# Altium schematic sheet BLEspresso.100.PowerSupplies.SchDoc(U_BLEspresso)
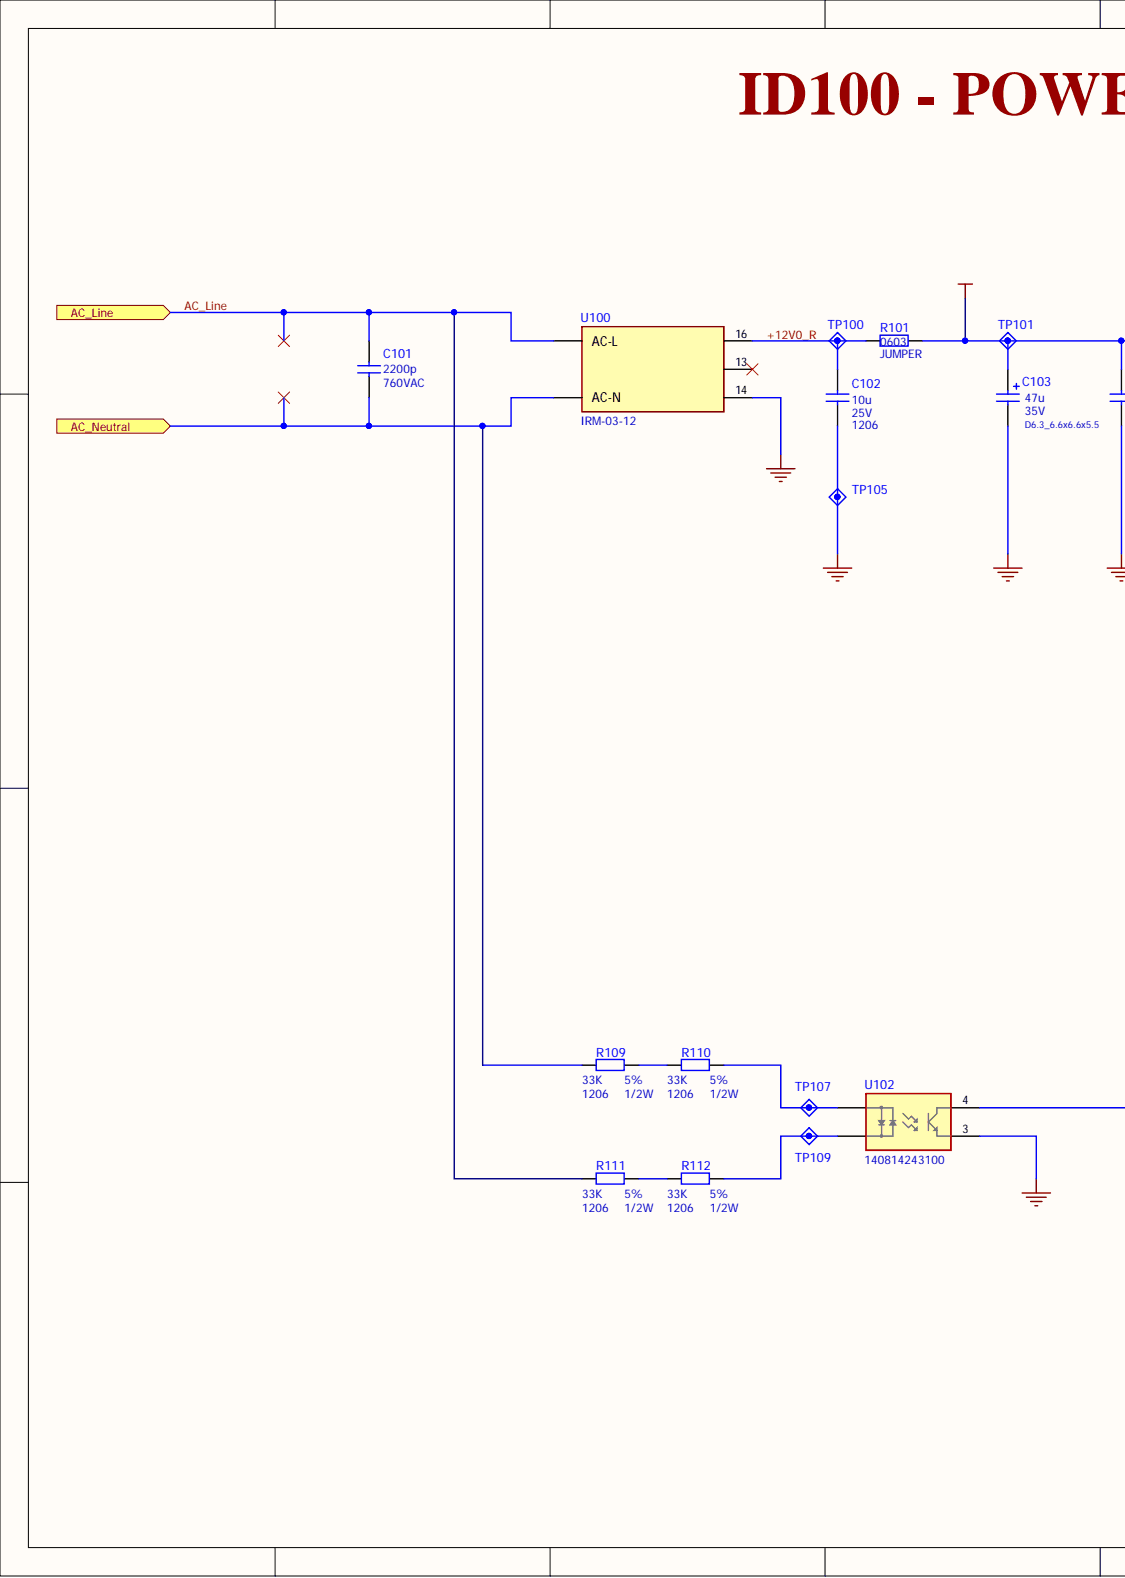
[diagram p0: BLEspresso.100.PowerSupplies.SchDoc, left]
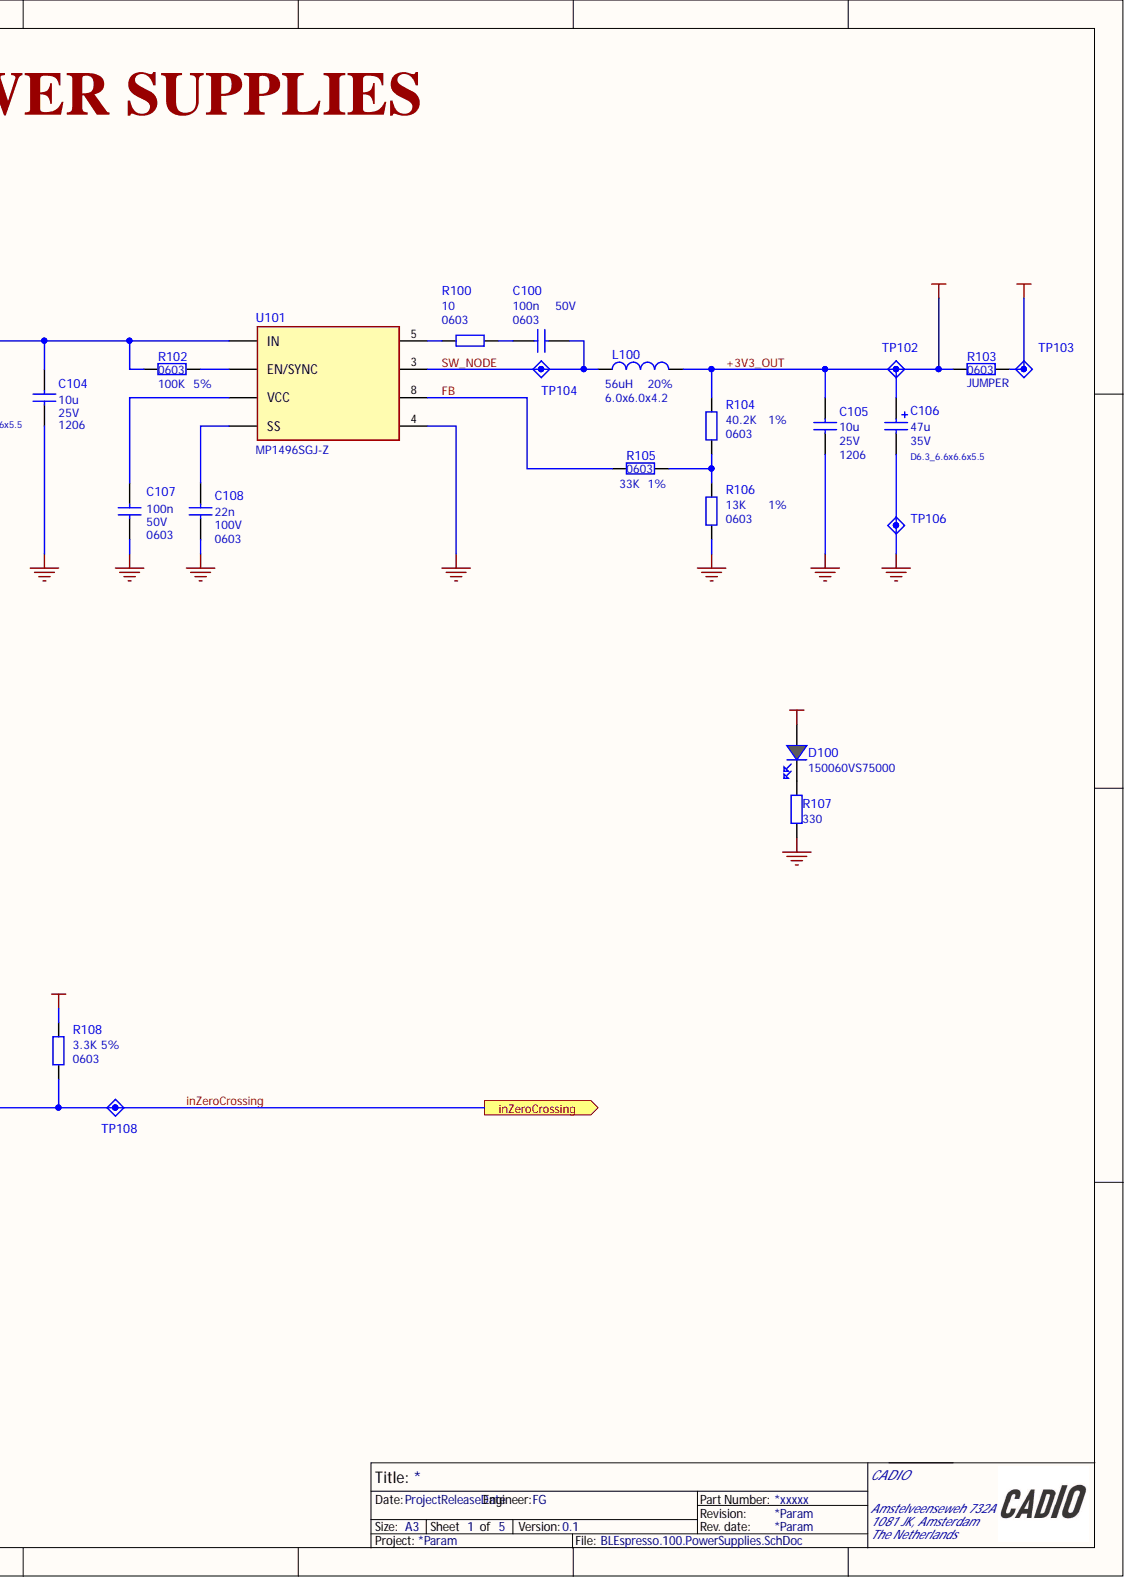
[diagram p1: BLEspresso.100.PowerSupplies.SchDoc, right]

## Parts
C100  Part Value 2: 50V  Part Value: 100n  Part Package: 0603  [CAP_0603_1608X90-N_MURATA_A]  (hidden comment: #NAME?)
C101  Part Value 2: 760VAC  Part Value: 2200p  [VISHAY_P1250_VY1_A]  (hidden comment: #NAME?)
C102  Part Value 2: 25V  Part Value: 10u  Part Package: 1206  [CAP_1206_3216X160-N_MURATA_A]  (hidden comment: #NAME?)
C103  Part Value 2: 35V  Part Value: 47u  Part Package: D6.3_6.6x6.6x5.5  [CAPAE_55_660X660X520L265X65-N_KEMET_A]  (hidden comment: #NAME?)
C104  Part Value 2: 25V  Part Value: 10u  Part Package: 1206  [CAP_1206_3216X160-N_MURATA_A]  (hidden comment: #NAME?)
C105  Part Value 2: 25V  Part Value: 10u  Part Package: 1206  [CAP_1206_3216X160-N_MURATA_A]  (hidden comment: #NAME?)
C106  Part Value 2: 35V  Part Value: 47u  Part Package: D6.3_6.6x6.6x5.5  [CAPAE_55_660X660X520L265X65-N_KEMET_A]  (hidden comment: #NAME?)
C107  Part Value 2: 50V  Part Value: 100n  Part Package: 0603  [CAP_0603_1608X90-N_MURATA_A]  (hidden comment: #NAME?)
C108  Part Value 2: 100V  Part Value: 22n  Part Package: 0603  [CAP_0603_1608X90-N_MURATA_A]  (hidden comment: #NAME?)
D100  Manufacturer Part Number: 150060VS75000  [LED_GREEN_0603-N_LITEON_A]  (hidden comment: #NAME?)
L100  Part Value 2: 20%  Part Value: 56uH  Part Package: 6.0x6.0x4.2  [BOURNS_SRN6045TA _A]  (hidden comment: #NAME?)
R100  Part Package: 0603  [RES_0603_1608X60-N_YAGEO_A]  (hidden comment: #NAME?)
R101  Part Value: JUMPER  Part Package: 0603  [RES_0603_1608X60-N_YAGEO_A]  (hidden comment: #NAME?)
R102  Part Value 2: 5%  Part Value: 100K  Part Package: 0603  [CAP_0603_1608X95-N_MURATA_A]  (hidden comment: #NAME?)
R103  Part Value: JUMPER  Part Package: 0603  [RES_0603_1608X60-N_YAGEO_A]  (hidden comment: #NAME?)
R104  Part Value: 40.2K  Part Package: 0603  [RES_0603_1608X60-N_YAGEO_A]  (hidden comment: #NAME?)
R105  Part Value 2: 1%  Part Value: 33K  Part Package: 0603  [RES_0603_1608X60-N_YAGEO_A]  (hidden comment: #NAME?)
R106  Part Value: 13K  Part Package: 0603  [RES_0603_1608X60-N_YAGEO_A]  (hidden comment: #NAME?)
R107  #NAME?  [RES_0603_1608X60-N_YAGEO_A]  (value not drawn)
R108  Part Value: 3.3K  Part Package: 0603  [RES_0603_1608X60-N_YAGEO_A]  (hidden comment: #NAME?)
R109  Part Value 3: 1/2W  Part Value 2: 5%  Part Value: 33K  Part Package: 1206  [RES_1206_3216X65-N_YAGEO_A]  (hidden comment: #NAME?)
R110  Part Value 3: 1/2W  Part Value 2: 5%  Part Value: 33K  Part Package: 1206  [RES_1206_3216X65-N_YAGEO_A]  (hidden comment: #NAME?)
R111  Part Value 3: 1/2W  Part Value 2: 5%  Part Value: 33K  Part Package: 1206  [RES_1206_3216X65-N_YAGEO_A]  (hidden comment: #NAME?)
R112  Part Value 3: 1/2W  Part Value 2: 5%  Part Value: 33K  Part Package: 1206  [RES_1206_3216X65-N_YAGEO_A]  (hidden comment: #NAME?)
TP100  Test Point 0D8 A  [TESTPOINT_0D8_A]  (value not drawn)  (no BOM)
TP101  Test Point 0D8 A  [TESTPOINT_0D8_A]  (value not drawn)  (no BOM)
TP102  Test Point 0D8 A  [TESTPOINT_0D8_A]  (value not drawn)  (no BOM)
TP103  Test Point 0D8 A  [TESTPOINT_0D8_A]  (value not drawn)  (no BOM)
TP104  Test Point 0D8 A  [TESTPOINT_0D8_A]  (value not drawn)  (no BOM)
TP105  Test Point 0D8 A  [TESTPOINT_0D8_A]  (value not drawn)  (no BOM)
TP106  Test Point 0D8 A  [TESTPOINT_0D8_A]  (value not drawn)  (no BOM)
TP107  Test Point 0D8 A  [TESTPOINT_0D8_A]  (value not drawn)  (no BOM)
TP108  Test Point 0D8 A  [TESTPOINT_0D8_A]  (value not drawn)  (no BOM)
TP109  Test Point 0D8 A  [TESTPOINT_0D8_A]  (value not drawn)  (no BOM)
U100  Manufacturer Part Number: IRM-03-12  [MEANWELL_IRM03_A]  (hidden comment: #NAME?)
U101  Manufacturer Part Number: MP1496SGJ-Z  [SOT23-8P65_290X160L40X30-N_MPS_A]  (hidden comment: #NAME?)
U102  Manufacturer Part Number: 140814243100  [SOP4P254_458X1015X360L80X145-N_EVERLIGHT_A]  (hidden comment: #NAME?)

## Ports
AC_Line, AC_Neutral, inZeroCrossing

## Nets
+3V3: R103.2 R108.2 TP103.1
+12V0: C103.1 C104.1 R101.2 R102.1 TP101.1 U101.2(IN)
GND: C102.2 C103.2 C104.2 C105.2 C106.2 C107.2 C108.2 R106.1 R107.1 TP105.1 TP106.1 U100.14 U101.4 U102.3
+3V3_OUT: C105.1 C106.1 D100.A L100.2 R103.1 R104.2 TP102.1
+12V0_R: C102.1 R101.1 TP100.1 U100.16
AC_Line: C101.1 R111.1 U100.1(AC-L) ; port AC_Line
AC_Neutral: C101.2 R109.1 U100.3(AC-N) ; port AC_Neutral
FB: R105.1 U101.8
inZeroCrossing: R108.1 TP108.1 U102.4 ; port inZeroCrossing
SW_NODE: C100.1 L100.1 TP104.1 U101.3
NetC100_2: C100.2 R100.2
NetC107_1: C107.1 U101.7(VCC)
NetC108_1: C108.1 U101.1(SS)
NetD100_C: D100.C R107.2
NetR100_1: R100.1 U101.5
NetR102_2: R102.2 U101.6(EN/SYNC)
NetR104_1: R104.1 R105.2 R106.2
NetR109_2: R109.2 R110.1
NetR110_2: R110.2 TP107.1 U102.1
NetR111_2: R111.2 R112.1
NetR112_2: R112.2 TP109.1 U102.2

Not connected: U100 13

## Library data of the parts
C100 C107 = 29: CAP CER 100 nF 50V X7R 0603; Obsolete Part: False; Manufacturer Part Number 3rd: GCM188L81H104KA57D; Manufacturer Part Number 2nd: 0603B104K500CT; Manufacturer Part Number: C0603C104K5RAC7867; Manufacturer 3rd: Murata Electronics; Manufacturer 2nd: Walsin Technology Corporation; Manufacturer: KEMET; Database Table Name: 01_Capacitors; Part Value 3: 10%; Package Type: SMT; Library Name: DB_Library.DbLib; Component Kind: Standard; Component ID: 29
C101 = 78: CAP CER 2200PF 760VAC Y5U RADIAL; Obsolete Part: False; Manufacturer Part Number: VY1222M43Y5UC6UV0; Manufacturer: Vishay Beyschlag/Draloric/BC Components; Database Table Name: 01_Capacitors; Package Type: THT; Library Name: DB_Library.DbLib; Component Kind: Standard; Component ID: 78
C102 C104 C105 = 69: CAP CER 10 uF 25V X7R 1206; Obsolete Part: False; Manufacturer Part Number 2nd: C3216X7R1E106K160AE; Manufacturer Part Number: GRM31CR71E106KA12L; Manufacturer 2nd: TDK Corporation; Manufacturer: Murata Electronics; Database Table Name: 01_Capacitors; Part Value 3: X7R; Package Type: SMT; Library Name: DB_Library.DbLib; Component Kind: Standard; Component ID: 69
C103 C106 = 80: 47 µF 35 V Aluminum Electrolytic Capacitors Radial, Can - SMD 2000 Hrs @ 105°C; Obsolete Part: False; Manufacturer Part Number: 865060543005; Manufacturer: Würth Elektronik; Database Table Name: 01_Capacitors; Part Value 3: 20%; Package Type: SMT; Library Name: DB_Library.DbLib; Component Kind: Standard; Component ID: 80
C108 = 43: CAP CER 22 nF 100V X7R 0603; Obsolete Part: False; Manufacturer Part Number 2nd: C0603C223K1RACTU; Manufacturer Part Number: CGA3E2X7R2A223K080AA; Manufacturer 2nd: KEMET; Manufacturer: TDK Corporation; Database Table Name: 01_Capacitors; Part Value 3: X7R; Package Type: SMT; Library Name: DB_Library.DbLib; Component Kind: Standard; Component ID: 43
D100 = 7: LED GREEN CLEAR 0603 SMD; Part Package: 0603; Obsolete Part: False; Manufacturer: Würth Elektronik; Database Table Name: 10_Optoelectronics; Part Value: Green; Package Type: SMT; Library Name: DB_Library.DbLib; Component Kind: Standard; Component ID: 7
L100 = 29: 56 µH Semi-Shielded Wirewound Inductor 1.4 A 230mOhm Nonstandard; Obsolete Part: False; Manufacturer Part Number: SRN6045TA-560M; Manufacturer: Bourns Inc.; Database Table Name: 03_Inductors_Coils_Chokes; Part Value 3: 1A4; Package Type: SMT; Library Name: DB_Library.DbLib; Component Kind: Standard; Component ID: 29
R100 = 94: RES 10 OHM 5% 1/10W 0603; Part Value: 10; Obsolete Part: False; Manufacturer Part Number 2nd: ESR03EZPJ100; Manufacturer Part Number: RC0603JR-0710RL; Manufacturer 2nd: Rohm Semiconductor; Manufacturer: YAGEO; Database Table Name: 02_Resistors; Part Value 3: 1/10W; Part Value 2: 5%; Package Type: SMT; Library Name: DB_Library.DbLib; Component Kind: Standard; Component ID: 94
R101 R103 = 9: RES 0 OHM JUMPER 1/10W 0603; Obsolete Part: False; Manufacturer Part Number 2nd: RMCF0603ZT0R00; Manufacturer Part Number: RC0603JR-070RL; Manufacturer 2nd: Stackpole Electronics Inc; Manufacturer: YAGEO; Database Table Name: 02_Resistors; Part Value 3: 1/10W; Part Value 2: 5%; Package Type: SMT; Library Name: DB_Library.DbLib; Component Kind: Standard; Component ID: 9
R102 = 102: 100 kOhms ±5% 0.1W, 1/10W Chip Resistor 0603 (1608 Metric) Automotive AEC-Q200 Thick Film; Obsolete Part: False; Manufacturer Part Number: ERJ-3GEYJ104V; Manufacturer: Panasonic Electronic Components; Database Table Name: 02_Resistors; Part Value 3: 1/10W; Package Type: SMT; Library Name: DB_Library.DbLib; Component Kind: Standard; Component ID: 102
R104 = 99: 40.2 kOhms ±1% 0.1W, 1/10W Chip Resistor 0603 (1608 Metric) Moisture Resistant Thick Film; Obsolete Part: False; Manufacturer Part Number: RC0603FR-0740K2L; Manufacturer: YAGEO; Database Table Name: 02_Resistors; Part Value 3: 1/10W; Part Value 2: 1%; Package Type: SMT; Library Name: DB_Library.DbLib; Component Kind: Standard; Component ID: 99
R105 = 101: 33 kOhms ±1% 0.1W, 1/10W Chip Resistor 0603 (1608 Metric) Moisture Resistant Thick Film; Obsolete Part: False; Manufacturer Part Number: RC0603FR-0733KL; Manufacturer: YAGEO; Database Table Name: 02_Resistors; Part Value 3: 1/10W; Package Type: SMT; Library Name: DB_Library.DbLib; Component Kind: Standard; Component ID: 101
R106 = 100: 13 kOhms ±1% 0.1W, 1/10W Chip Resistor 0603 (1608 Metric) Moisture Resistant Thick Film; Obsolete Part: False; Manufacturer Part Number: RC0603FR-0713KL; Manufacturer: YAGEO; Database Table Name: 02_Resistors; Part Value 3: 1/10W; Part Value 2: 1%; Package Type: SMT; Library Name: DB_Library.DbLib; Component Kind: Standard; Component ID: 100
R107 = 108: 330 Ohms ± 5% 0.1W, 1/10W Chip Resistor 0603 (1608 Metric) Automotive AEC-Q200 Thick Film; Part Value: 330; Part Package: 0603; Obsolete Part: False; Manufacturer Part Number: ERJ-3GEYJ331V; Manufacturer: Panasonic Electronic Components; Database Table Name: 02_Resistors; Part Value 3: 1/10W; Part Value 2: 5%; Package Type: SMT; Library Name: DB_Library.DbLib; Component Kind: Standard; Component ID: 108
R108 = 98: 3.3 kOhms ±5% 0.1W, 1/10W Chip Resistor 0603 (1608 Metric) Moisture Resistant Thick Film; Obsolete Part: False; Manufacturer Part Number: RC0603JR-073K3L; Manufacturer: YAGEO; Database Table Name: 02_Resistors; Part Value 3: 1/10W; Part Value 2: 5%; Package Type: SMT; Library Name: DB_Library.DbLib; Component Kind: Standard; Component ID: 98
R109-R112 = 107: 33k Ohms ±5% 0.5W, 1/2W Chip Resistor 1206 (3216 Metric) Automotive AEC-Q200, Pulse Withstanding Thick Film; Obsolete Part: False; Manufacturer Part Number: ESR18EZPJ333; Manufacturer: Rohm Semiconductor; Database Table Name: 02_Resistors; Package Type: SMT; Library Name: DB_Library.DbLib; Component Kind: Standard; Component ID: 107
TP100-TP109 = 1: Pad Diamater 0.8mm; Obsolete Part: False; Database Table Name: 30_Manufacturing_Elements; Part: Test Point 0D8 A; Library Name: DB_Library.DbLib; Component ID: 1
U100 = 3: AC/DC CONVERTER 12V 3W; Part Value: 12Vout; Part Package: 5-DIP; Obsolete Part: False; Manufacturer: MEAN WELL USA Inc.; Database Table Name: 18_Power_Supplies; Part Value 2: 3W; Package Type: THT; Library Name: DB_Library.DbLib; Component Kind: Standard; Component ID: 3
U101 = 32: Buck Switching Regulator IC Positive Adjustable 0.8V 1 Output 2A SOT-23-8 Thin, TSOT-23-8; Obsolete Part: False; Manufacturer: Monolithic Power Systems Inc.; Database Table Name: 15_IC_PMIC; Part Type: Buck; Part Package: SOT-23-8; Package Type: SMT; Library Name: DB_Library.DbLib; Component Kind: Standard; Component ID: 32
U102 = 8: Optoisolator Transistor Output 5000Vrms 1 Channel 4-DIP-SL; Obsolete Part: False; Manufacturer: Würth Elektronik; Database Table Name: 09_Isolators; Part Package: 4-DIP-SL; Package Type: SMT; Library Name: DB_Library.DbLib; Component Kind: Standard; Component ID: 8
